# Altium schematic sheet FPGA.SchDoc(FPGA)
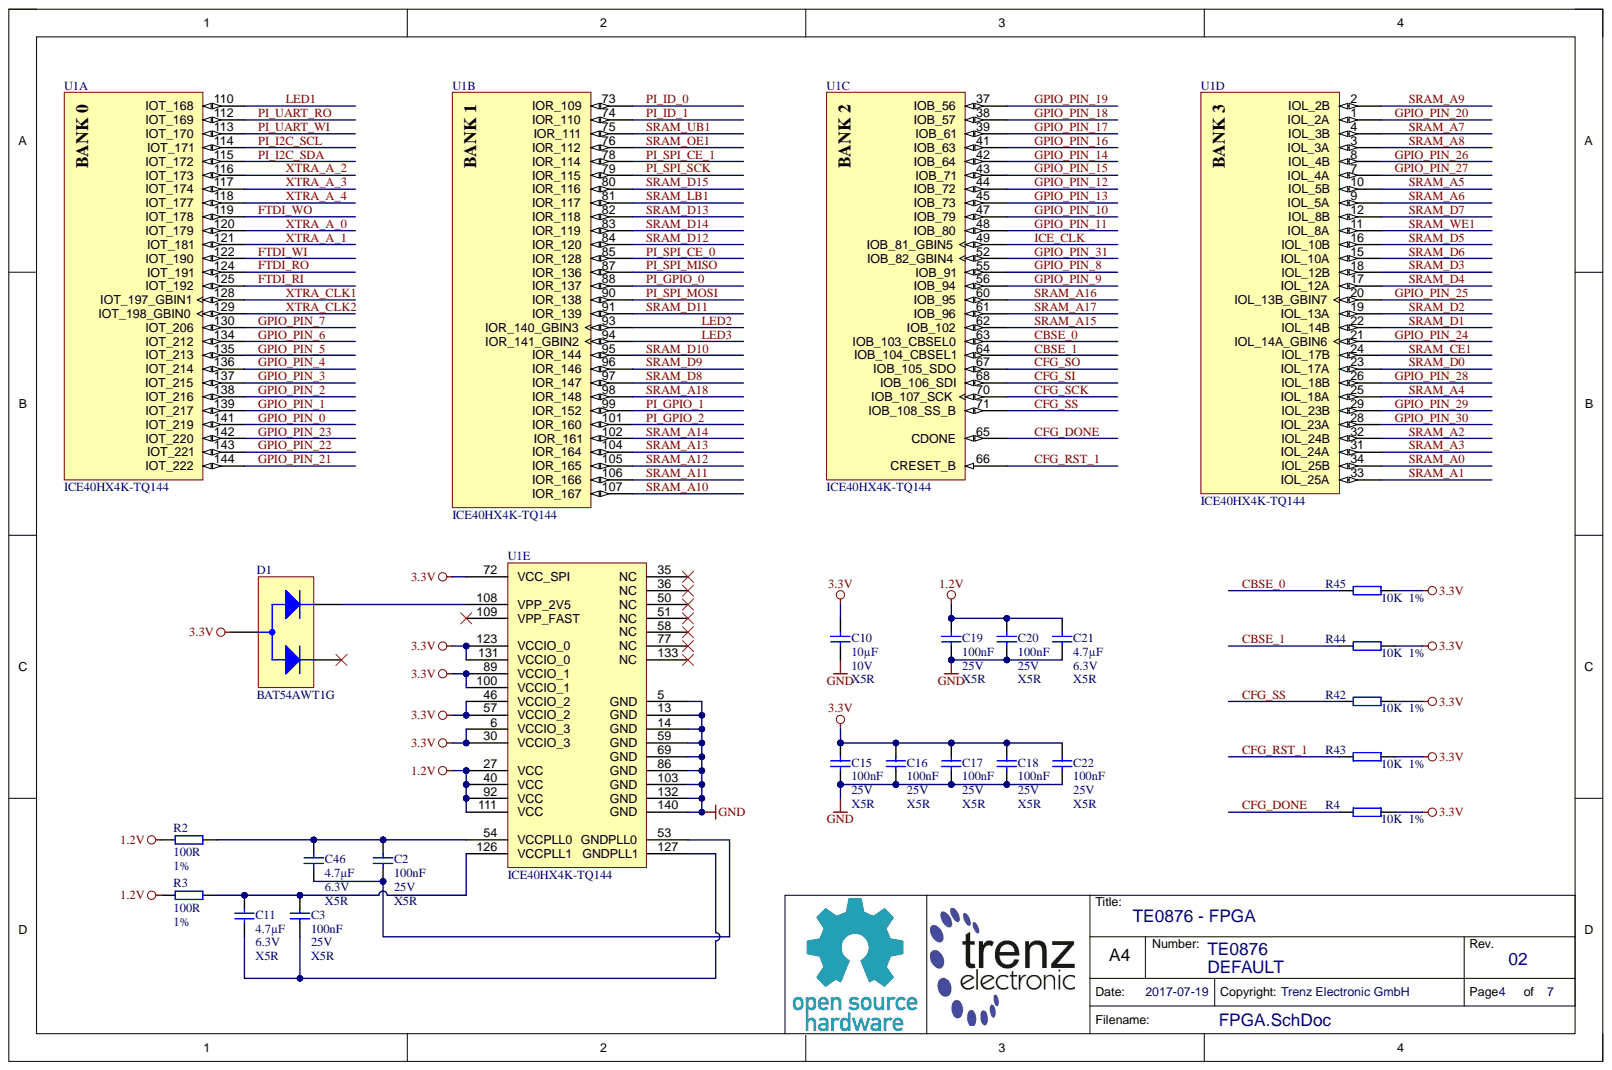
[diagram p0: FPGA.SchDoc]

## Parts
C2  100nF  Technology: X5R  Voltage: 25V  [CAPC1005X55N]
C3  100nF  Technology: X5R  Voltage: 25V  [CAPC1005X55N]
C10  10µF  Technology: X5R  Voltage: 10V  [CAPC2012X135N]
C11  4.7µF  Technology: X5R  Voltage: 6.3V  [CAPC1005X60N]
C15  100nF  Technology: X5R  Voltage: 25V  [CAPC1005X55N]
C16  100nF  Technology: X5R  Voltage: 25V  [CAPC1005X55N]
C17  100nF  Technology: X5R  Voltage: 25V  [CAPC1005X55N]
C18  100nF  Technology: X5R  Voltage: 25V  [CAPC1005X55N]
C19  100nF  Technology: X5R  Voltage: 25V  [CAPC1005X55N]
C20  100nF  Technology: X5R  Voltage: 25V  [CAPC1005X55N]
C21  4.7µF  Technology: X5R  Voltage: 6.3V  [CAPC1005X60N]
C22  100nF  Technology: X5R  Voltage: 25V  [CAPC1005X55N]
C46  4.7µF  Voltage: 6.3V  [CAPC1005X60N]
D1  BAT54AWT1G  [SOT65P210X110-3N]
R2  100R  [RESC1005X40N]
R3  100R  Tolerance: 1%  [RESC1005X40N]
R4  10K  Tolerance: 1%  [RESC1005X40N]
R42  10K  Tolerance: 1%  [RESC1005X40N]
R43  10K  Tolerance: 1%  [RESC1005X40N]
R44  10K  Tolerance: 1%  [RESC1005X40N]
R45  10K  Tolerance: 1%  [RESC1005X40N]
U1  ICE40HX4K-TQ144  [QFP50P2200X2200X160-144N]  (parts A,B,C,D,E)

## Nets
1.2V: C19.1 C20.1 C21.1 R2.1 R3.1 U1.27(VCC) U1.40(VCC) U1.92(VCC) U1.111(VCC)
3.3V: C10.1 C15.1 C16.1 C17.1 C18.1 C22.1 D1.3 R4.1 R42.1 R43.1 R44.1 R45.1 U1.6(VCCIO_3) U1.30(VCCIO_3) U1.46(VCCIO_2) U1.57(VCCIO_2) U1.72(VCC_SPI) U1.89(VCCIO_1) U1.100(VCCIO_1) U1.123(VCCIO_0) U1.131(VCCIO_0)
GND: C10.2 C15.2 C16.2 C17.2 C18.2 C19.2 C20.2 C21.2 C22.2 U1.5(GND) U1.13(GND) U1.14(GND) U1.59(GND) U1.69(GND) U1.86(GND) U1.103(GND) U1.132(GND) U1.140(GND)
CBSE_0: R45.2 U1.63(IOB_103_CBSEL0)
CBSE_1: R44.2 U1.64(IOB_104_CBSEL1)
CFG_DONE: R4.2 U1.65(CDONE)
CFG_RST_1: R43.2 U1.66(CRESET_B)
CFG_SCK: U1.70(IOB_107_SCK)
CFG_SI: U1.68(IOB_106_SDI)
CFG_SO: U1.67(IOB_105_SDO)
CFG_SS: R42.2 U1.71(IOB_108_SS_B)
FTDI_RI: U1.125(IOT_192)
FTDI_RO: U1.124(IOT_191)
FTDI_WI: U1.122(IOT_190)
FTDI_WO: U1.119(IOT_178)
GPIO_PIN_0: U1.141(IOT_219)
GPIO_PIN_1: U1.139(IOT_217)
GPIO_PIN_2: U1.138(IOT_216)
GPIO_PIN_3: U1.137(IOT_215)
GPIO_PIN_4: U1.136(IOT_214)
GPIO_PIN_5: U1.135(IOT_213)
GPIO_PIN_6: U1.134(IOT_212)
GPIO_PIN_7: U1.130(IOT_206)
GPIO_PIN_8: U1.55(IOB_91)
GPIO_PIN_9: U1.56(IOB_94)
GPIO_PIN_10: U1.47(IOB_79)
GPIO_PIN_11: U1.48(IOB_80)
GPIO_PIN_12: U1.44(IOB_72)
GPIO_PIN_13: U1.45(IOB_73)
GPIO_PIN_14: U1.42(IOB_64)
GPIO_PIN_15: U1.43(IOB_71)
GPIO_PIN_16: U1.41(IOB_63)
GPIO_PIN_17: U1.39(IOB_61)
GPIO_PIN_18: U1.38(IOB_57)
GPIO_PIN_19: U1.37(IOB_56)
GPIO_PIN_20: U1.1(IOL_2A)
GPIO_PIN_21: U1.144(IOT_222)
GPIO_PIN_22: U1.143(IOT_221)
GPIO_PIN_23: U1.142(IOT_220)
GPIO_PIN_24: U1.21(IOL_14A_GBIN6)
GPIO_PIN_25: U1.20(IOL_13B_GBIN7)
GPIO_PIN_26: U1.8(IOL_4B)
GPIO_PIN_27: U1.7(IOL_4A)
GPIO_PIN_28: U1.26(IOL_18B)
GPIO_PIN_29: U1.29(IOL_23B)
GPIO_PIN_30: U1.28(IOL_23A)
GPIO_PIN_31: U1.52(IOB_82_GBIN4)
ICE_CLK: U1.49(IOB_81_GBIN5)
LED1: U1.110(IOT_168)
LED2: U1.93(IOR_140_GBIN3)
LED3: U1.94(IOR_141_GBIN2)
PI_GPIO_0: U1.88(IOR_137)
PI_GPIO_1: U1.99(IOR_152)
PI_GPIO_2: U1.101(IOR_160)
PI_I2C_SCL: U1.114(IOT_171)
PI_I2C_SDA: U1.115(IOT_172)
PI_ID_0: U1.73(IOR_109)
PI_ID_1: U1.74(IOR_110)
PI_SPI_CE_0: U1.85(IOR_128)
PI_SPI_CE_1: U1.78(IOR_114)
PI_SPI_MISO: U1.87(IOR_136)
PI_SPI_MOSI: U1.90(IOR_138)
PI_SPI_SCK: U1.79(IOR_115)
PI_UART_RO: U1.112(IOT_169)
PI_UART_WI: U1.113(IOT_170)
SRAM_A0: U1.34(IOL_25B)
SRAM_A1: U1.33(IOL_25A)
SRAM_A2: U1.32(IOL_24B)
SRAM_A3: U1.31(IOL_24A)
SRAM_A4: U1.25(IOL_18A)
SRAM_A5: U1.10(IOL_5B)
SRAM_A6: U1.9(IOL_5A)
SRAM_A7: U1.4(IOL_3B)
SRAM_A8: U1.3(IOL_3A)
SRAM_A9: U1.2(IOL_2B)
SRAM_A10: U1.107(IOR_167)
SRAM_A11: U1.106(IOR_166)
SRAM_A12: U1.105(IOR_165)
SRAM_A13: U1.104(IOR_164)
SRAM_A14: U1.102(IOR_161)
SRAM_A15: U1.62(IOB_102)
SRAM_A16: U1.60(IOB_95)
SRAM_A17: U1.61(IOB_96)
SRAM_A18: U1.98(IOR_148)
SRAM_CE1: U1.24(IOL_17B)
SRAM_D0: U1.23(IOL_17A)
SRAM_D1: U1.22(IOL_14B)
SRAM_D2: U1.19(IOL_13A)
SRAM_D3: U1.18(IOL_12B)
SRAM_D4: U1.17(IOL_12A)
SRAM_D5: U1.16(IOL_10B)
SRAM_D6: U1.15(IOL_10A)
SRAM_D7: U1.12(IOL_8B)
SRAM_D8: U1.97(IOR_147)
SRAM_D9: U1.96(IOR_146)
SRAM_D10: U1.95(IOR_144)
SRAM_D11: U1.91(IOR_139)
SRAM_D12: U1.84(IOR_120)
SRAM_D13: U1.82(IOR_118)
SRAM_D14: U1.83(IOR_119)
SRAM_D15: U1.80(IOR_116)
SRAM_LB1: U1.81(IOR_117)
SRAM_OE1: U1.76(IOR_112)
SRAM_UB1: U1.75(IOR_111)
SRAM_WE1: U1.11(IOL_8A)
XTRA_A_0: U1.120(IOT_179)
XTRA_A_1: U1.121(IOT_181)
XTRA_A_2: U1.116(IOT_173)
XTRA_A_3: U1.117(IOT_174)
XTRA_A_4: U1.118(IOT_177)
XTRA_CLK1: U1.128(IOT_197_GBIN1)
XTRA_CLK2: U1.129(IOT_198_GBIN0)
NetC2_1: C2.1 C46.1 R2.2 U1.54(VCCPLL0)
NetC2_2: C2.2 C46.2 U1.53(GNDPLL0)
NetC3_1: C3.1 C11.1 R3.2 U1.126(VCCPLL1)
NetC3_2: C3.2 C11.2 U1.127(GNDPLL1)
NetD1_2: D1.2 U1.108(VPP_2V5)

Not connected: D1 1; U1 35(NC) 36(NC) 50(NC) 51(NC) 58(NC) 77(NC) 109(VPP_FAST) 133(NC)

## Library data of the parts
C2 C3 C15-C20 C22 = 22472: KON 100nF 25V X5R SMD ±10%; Database Table Name: C_1005_0402; Mixed: SMT; Preferred: Yes; Value: 100n; AN: 22472; Case: 1005 (0402); Component Kind: Standard; Library Name: TE.DbLib
C10 = 28014: KON 10µF 10V X5R 10% SMD; Database Table Name: C_2012_0805; Mixed: SMT; Value: 10u; AN: 28014; Case: 2012 (0805); Component Kind: Standard; Library Name: TE.DbLib; Tolerance: 10%
C11 C21 C46 = 24719: KON 4.7µF 6.3V X5R SMD 20%; Database Table Name: C_1005_0402; Mixed: SMT; Preferred: Yes; Value: 4.7u; AN: 24719; Case: 1005 (0402); Component Kind: Standard; Library Name: TE.DbLib; Tolerance: 20%
  C46: Technology: X5R
D1 = 26805: Database Table Name: DIODE; Mixed: SMT; AN: 26805; Case: SOT323; Component Kind: Standard; Description: BAT54AWT1G; H-ART: BAT54AWT1G; Library Name: TE.DbLib; HelpURL: B:\ad\adlib\datasheet\BAT54AW
R2 R3 = 22507: WID SMD 100R 1% 0,063W; Database Table Name: R_1005_0402; Mixed: SMT; Preferred: Yes; Value: 100; AN: 22507; Case: 1005 (0402); Component Kind: Standard; Library Name: TE.DbLib; Technology: TK100
  R2: Tolerance: 1%
R4 R42-R45 = 22750: WID SMD 10K 1% 0,063W; Database Table Name: R_1005_0402; Mixed: SMT; Preferred: Yes; AN: 22750; Case: 1005 (0402); Component Kind: Standard; Library Name: TE.DbLib; Technology: TK100; Value: 10K
U1 = 28049: Database Table Name: PROG_LOGIC; Mixed: SMT; AN: 28049; Case: TQ144; Component Kind: Standard; Description: ICE40HX4K-TQ144; H-ART: ICE40HX4K-TQ144; Library Name: TE.DbLib; HelpURL: B:\AD\adlib\datasheet\ICE40
